# Altium schematic sheet Sheet2.SchDoc
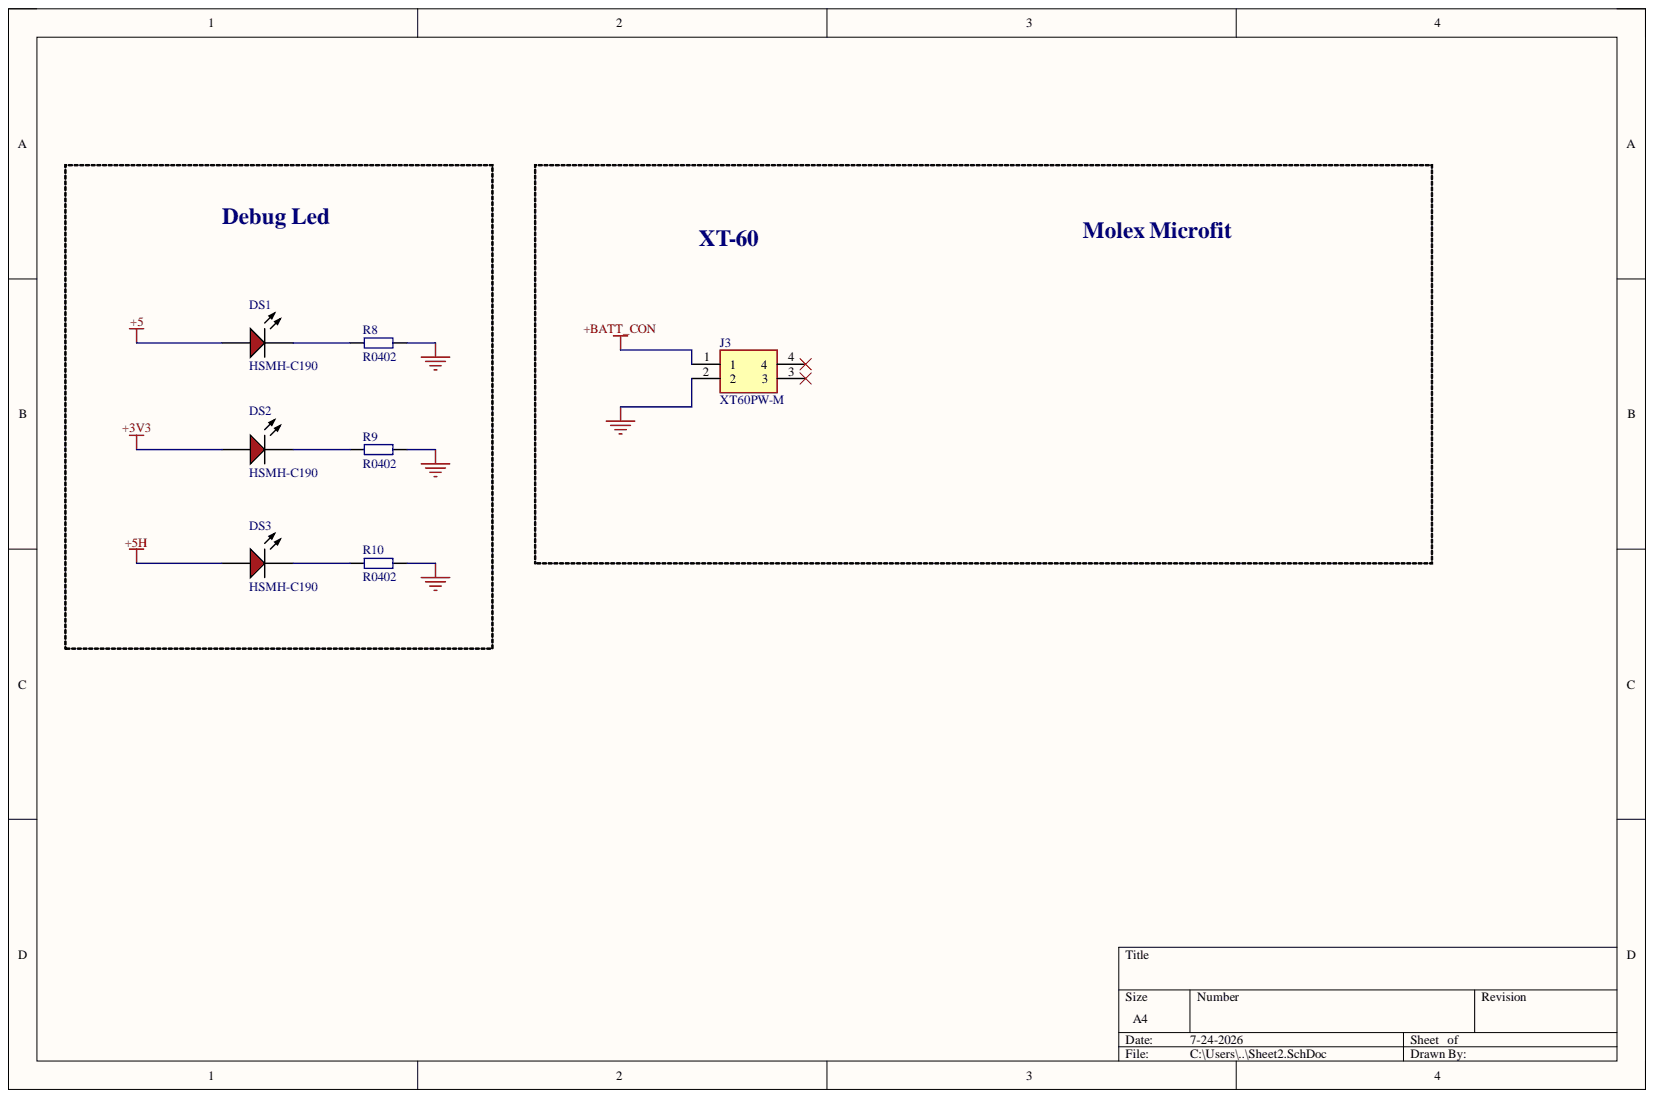
[diagram p0: Sheet2.SchDoc]

## Parts
DS1  HSMH-C190  [AVAG-HSMX-C190_V]
DS2  HSMH-C190  [AVAG-HSMX-C190_V]
DS3  HSMH-C190  [AVAG-HSMX-C190_V]
J3  XT60PW-M  [XT60PWM]
R8  R0402  [RES_0402]
R9  R0402  [RES_0402]
R10  R0402  [RES_0402]

## Nets
+3V3: DS2.1
+5: DS1.1
+5H: DS3.1
+BATT_CON: J3.1
GND: J3.2 R8.2 R9.2 R10.2
NetDS1_2: DS1.2 R8.1
NetDS2_2: DS2.2 R9.1
NetDS3_2: DS3.2 R10.1

Not connected: J3 3 4

## Library data of the parts
DS1-DS3 = CMP-007-00014-2: LED Uni-Color Red, 65 mW, 20 mA, -40 to 85 degC, 2-Pin SMD, RoHS, Tape and Reel; Manufacturer: Avago; Forward Current: 20mA; Forward Voltage: 1.8V; Lens Style: Diffused; Luminous Intensity: 17mcd; Power: 78mW; Viewing Angle: 170degrees; Case/Package: 0603; Colour: Red; Component Kind: Standard; Datasheet URL: http://docs.avagotech.com/docs/AV02-0551EN; Datasheet Version: Mar-12; Illumination Colour: Red; Manufacturer URL: http://www.avagotech.com/; Max Operating Temperature: 85°C; Min Operating Temperature: -40°C; Mounting Technology: SM; Nominal Vf: 1.8V; Package Description: 2-Pin Surface Mount Device, Body 1.6 x 0.8 mm, Height 0.9 mm; Package Quantity: 4000; Package Reference: HSMX-C190; Packaging: TapeandReel; Pins: 2; RoHS Compliant: True; Library Name: Shravan Talapady
J3 = XT60PW-M: Connector; Manufacturer_Name: Changzou Amass Elec; Component Kind: Standard; Datasheet Link: https://www.tme.eu/Document/9b8d0c5eb7094295f3d3112c214d3ade/XT60PW%20SPEC.pdf; Height: 8.4mm; Manufacturer_Part_Number: XT60PW-M; Library Name: Powersch.SchLib
R8-R10 = RES_0402: Resistor SMD 0402; Component Kind: Standard; Library Name: Powersch.SchLib
